# Altium schematic sheet Power.SchDoc
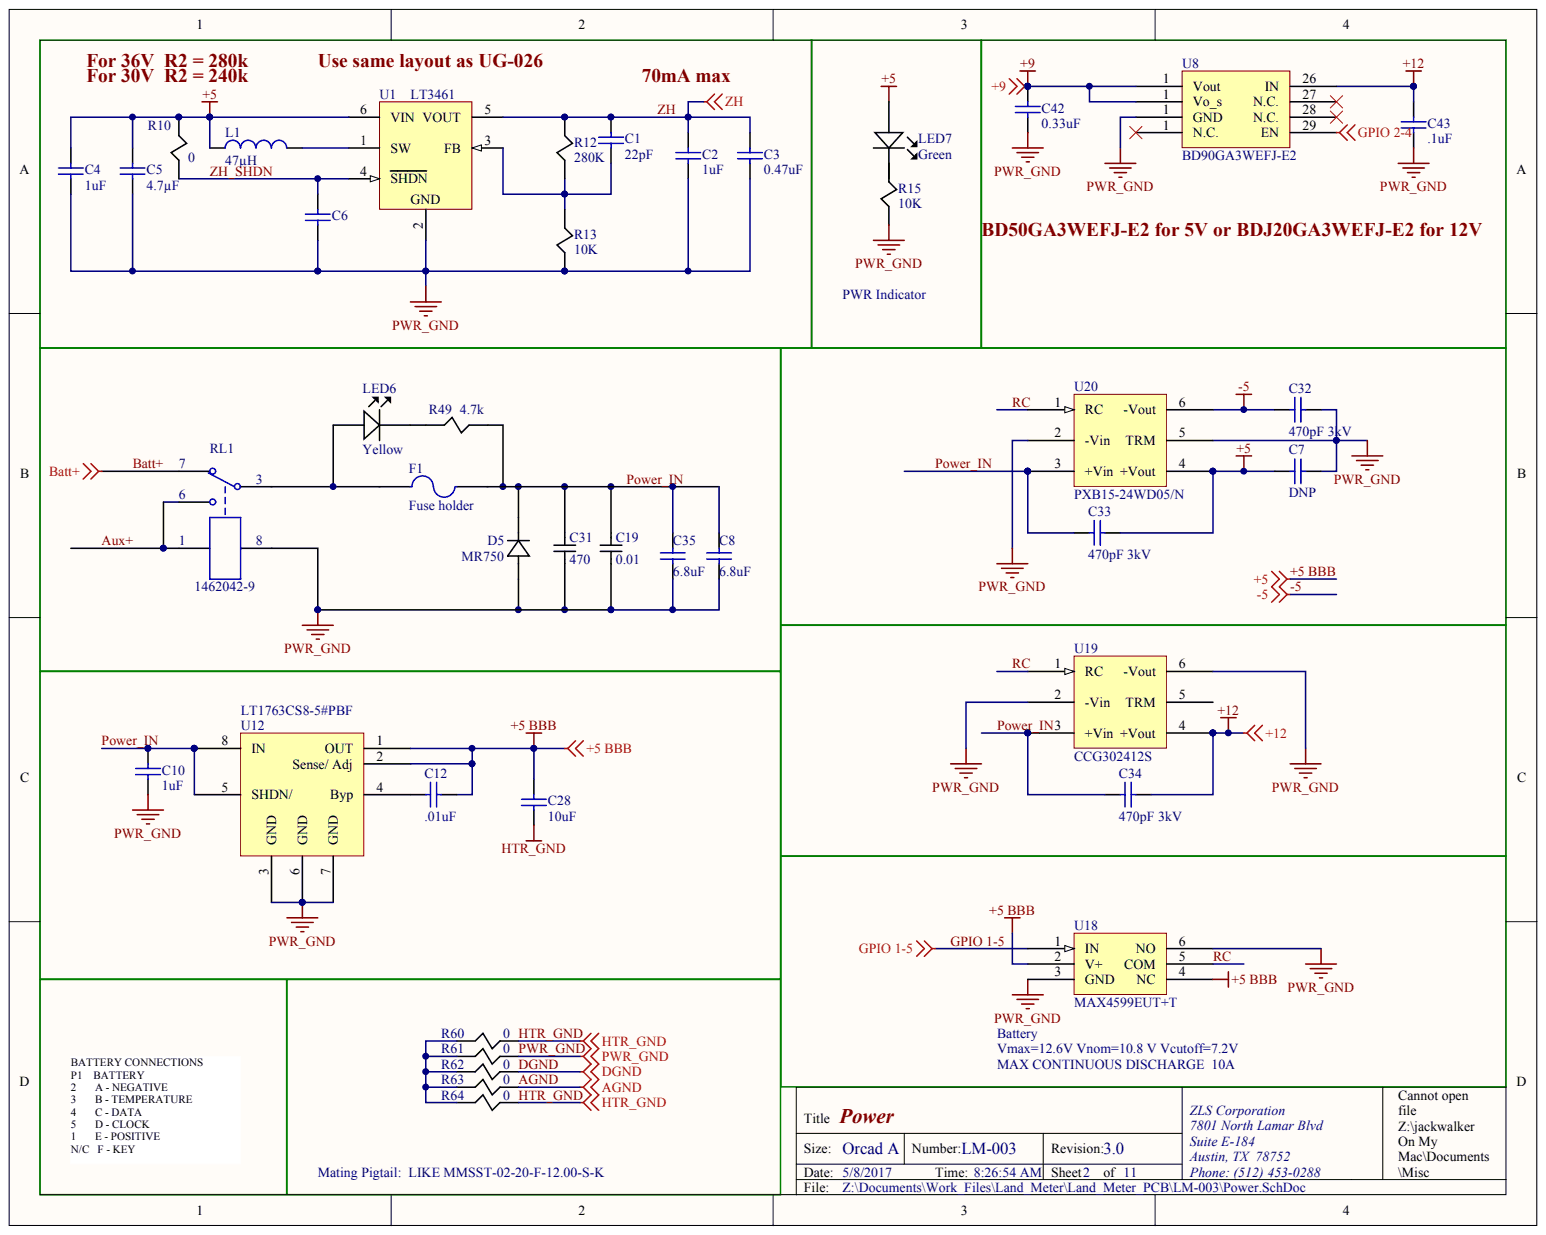
[diagram p0: Power.SchDoc]

## Parts
C1  22pF
C2  1uF
C3  0.47uF
C4  1uF
C5  4.7µF
C6  0.047µF  (value not drawn)
C7  DNP  Capacitance: 470pF
C8  6.8uF
C10  1uF
C12  .01uF
C19  0.01
C28  10uF
C31  470
C32  470pF 3kV  Capacitance: 470pF
C33  470pF 3kV  Capacitance: 470pF
C34  470pF 3kV  Capacitance: 470pF
C35  6.8uF
C42  0.33uF
C43  .1uF
D5  MR750
F1  Fuse holder
L1  47µH
LED6  Yellow
LED7  Green
R10  0
R12  280K
R13  10K
R15  10K
R49  4.7k
R60  0
R61  0
R62  0
R63  0
R64  0
RL1  1462042-9
U1  LT3461
U8  BD90GA3WEFJ-E2
U12  LT1763CS8-5#PBF
U18  MAX4599EUT+T
U19  CCG302412S
U20  PXB15-24WD05/N

## Nets
+5: C4.2 C5.2 C7.1 C33.2 L1.1 LED7.A R10.2 U1.6(VIN) U20.4(+Vout)
+9: C42.2 U8.1
+12: C34.2 C43.2 U8.26(IN) U19.4(+Vout)
GPIO 2-4: U8.29(EN)
+5 BBB: C12.2 C28.2 U12.1(OUT) U12.2(Sense/_Adj) U18.2(V+) U18.4(NC)
-5: C32.1 U20.6(-Vout)
AGND: R63.2
AUX+: RL1.3 RL1.5
BATT+: RL1.2
DGND: R62.2
GPIO 1-5: U18.1(IN)
HTR_GND: C28.1 R60.2 R64.2
POWER_IN: C8.2 C10.2 C19.1 C31.1 C33.1 C34.1 C35.2 D5.K F1.2 R49.2 U12.5(SHDN/) U12.8(IN) U19.3(+Vin) U20.3(+Vin)
PWR_GND: C2.1 C3.1 C4.1 C5.1 C6.1 C7.2 C8.1 C10.1 C19.2 C31.2 C32.2 C35.1 C42.1 C43.1 D5.A R13.1 R15.1 R61.2 RL1.4 U1.2 U8.1 U12.3(GND) U12.6(GND) U12.7(GND) U18.3(GND) U18.6(NO) U19.2(-Vin) U19.6(-Vout) U20.2(-Vin) U20.5(TRM)
RC: U18.5(COM) U19.1(RC) U20.1(RC)
ZH: C1.2 C2.2 C3.2 R12.2 U1.5(VOUT)
ZH_SHDN: C6.2 R10.1 U1.4(SHDN)
NetC1_1: C1.1 R12.1 R13.2 U1.3(FB)
NetC12_1: C12.1 U12.4(Byp)
NetF1_1: F1.1 LED6.A RL1.1
NetL1_2: L1.2 U1.1(SW)
NetLED6_K: LED6.K R49.1
NetR60_1: R60.1 R61.1 R62.1 R63.1 R64.1

Not connected: LED7 K; R15 2; U8 27(N.C.) 28(N.C.); U19 5(TRM)

## Library data of the parts
C1 = (no symbol name): Manufacturer 1: AVX Corporation; Manufacturer Part Number 1: 06035C220JAT2A; Description 1: CAP CER 22PF 50V X7R 0603; Category 1: Capacitors; Tolerance: ±5%; Voltage - Rated: 50V; Temperature Coefficient: X7R; Supplier 1: Digi-Key; Supplier Part Number 1: 478-6204-1-ND; RoHS 1: RoHS Compliant; Stock 1: 63351; Pricing 1: 1=0.2, 10=0.136, 25=0.0996, 50=0.0816, 100=0.0688, 250=0.05616, 500=0.0471, 1000=0.04166 (USD); Packaging: Cut Tape (CT); Part Status: Active; Capacitance: 22pF; Operating Temperature: -55°C ~ 125°C; Features: -; Ratings: -; Applications: General Purpose; Mounting Type: Surface Mount, MLCC; Package / Case: 0603 (1608 Metric); Size / Dimension: 0.063" L x 0.032" W (1.60mm x 0.81mm); Height - Seated (Max): -; Thickness (Max): 0.035" (0.90mm); Lead Spacing: -; Lead Style: -; ComponentLink1URL: http://datasheets.avx.com/X7RDielectric.pdf; ComponentLink1Description: http://datasheets.avx.com/X7RDielectric.pdf
C2 = (no symbol name): Manufacturer 1: Murata Electronics North America; Manufacturer Part Number 1: GRM32RR71H105KA01L; Description 1: CAP CER 1UF 50V X7R 1210; Category 1: Capacitors; Capacitance: 1µF; Tolerance: ±10%; Voltage - Rated: 50V; Temperature Coefficient: X7R; Supplier 1: Digi-Key; Supplier Part Number 1: 490-1863-1-ND; RoHS 1: RoHS Compliant; Stock 1: 29439; Pricing 1: 1=0.35, 10=0.242, 25=0.198, 50=0.169, 100=0.1449, 250=0.12076, 500=0.10868 (USD); Packaging: Cut Tape (CT); Part Status: Active; Operating Temperature: -55°C ~ 125°C; Features: -; Ratings: -; Applications: General Purpose; Mounting Type: Surface Mount, MLCC; Package / Case: 1210 (3225 Metric); Size / Dimension: 0.126" L x 0.098" W (3.20mm x 2.50mm); Height - Seated (Max): -; Thickness (Max): 0.079" (2.00mm); Lead Spacing: -; Lead Style: -; ComponentLink1URL: http://search.murata.co.jp/Ceramy/image/img/A01X/G101/ENG/GRM32RR71H105KA01-01.pdf; ComponentLink1Description: http://search.murata.co.jp/Ceramy/image/img/A01X/G101/ENG/GRM32RR71H105KA01-01.pdf
C3 = (no symbol name): Manufacturer 1: Murata Electronics North America; Manufacturer Part Number 1: GRM31MR71H474KA01L; Description 1: CAP CER 0.47UF 50V X7R 1206; Category 1: Capacitors; Capacitance: 0.47µF; Tolerance: ±10%; Voltage - Rated: 50V; Temperature Coefficient: X7R; Supplier 1: Digi-Key; Supplier Part Number 1: 490-1781-1-ND; RoHS 1: RoHS Compliant; Stock 1: 12711; Pricing 1: 1=0.43, 10=0.305, 25=0.2608, 50=0.2308, 100=0.201, 250=0.17124, 500=0.14892, 1000=0.12658 (USD); Packaging: Cut Tape (CT); Part Status: Active; Operating Temperature: -55°C ~ 125°C; Features: -; Ratings: -; Applications: General Purpose; Mounting Type: Surface Mount, MLCC; Package / Case: 1206 (3216 Metric); Size / Dimension: 0.126" L x 0.063" W (3.20mm x 1.60mm); Height - Seated (Max): -; Thickness (Max): 0.049" (1.25mm); Lead Spacing: -; Lead Style: -; ComponentLink1URL: http://www.murata.com/~/media/webrenewal/support/library/catalog/products/capacitor/mlcc/c02e.ashx; ComponentLink1Description: http://www.murata.com/~/media/webrenewal/support/library/catalog/products/capacitor/mlcc/c02e.ashx
C4 = (no symbol name): Manufacturer 1: Taiyo Yuden; Manufacturer Part Number 1: EMK212B7105KG-T; Description 1: CAP CER 1UF 16V X7R 0805; Category 1: Capacitors; Capacitance: 1µF; Tolerance: ±10%; Voltage - Rated: 16V; Temperature Coefficient: X7R; Supplier 1: Digi-Key; Supplier Part Number 1: 587-1283-1-ND; RoHS 1: RoHS Compliant; Stock 1: 42737; Pricing 1: 1=0.13, 10=0.089, 25=0.0652, 50=0.0508, 100=0.042, 250=0.03476, 500=0.02946, 1000=0.02439 (USD); Packaging: Cut Tape (CT); Part Status: Active; Operating Temperature: -55°C ~ 125°C; Features: -; Ratings: -; Applications: General Purpose; Mounting Type: Surface Mount, MLCC; Package / Case: 0805 (2012 Metric); Size / Dimension: 0.079" L x 0.049" W (2.00mm x 1.25mm); Height - Seated (Max): -; Thickness (Max): 0.053" (1.35mm); Lead Spacing: -; Lead Style: -; ComponentLink1URL: http://www.yuden.co.jp/productdata/catalog/en/mlcc06_e.pdf; ComponentLink1Description: http://www.yuden.co.jp/productdata/catalog/en/mlcc06_e.pdf
C5 = (no symbol name): Manufacturer 1: Taiyo Yuden; Manufacturer Part Number 1: EMK316B7475KL-T; Description 1: CAP CER 4.7UF 16V X7R 1206; Category 1: Capacitors; Tolerance: ±10%; Voltage - Rated: 16V; Temperature Coefficient: X7R; Supplier 1: Digi-Key; Supplier Part Number 1: 587-1331-1-ND; RoHS 1: RoHS Compliant; Stock 1: 42774; Pricing 1: 1=0.25, 10=0.17, 25=0.1364, 50=0.1136, 100=0.0965, 250=0.07664, 500=0.06812, 1000=0.0596 (USD); Packaging: Cut Tape (CT); Part Status: Active; Capacitance: 4.7µF; Operating Temperature: -55°C ~ 125°C; Features: -; Ratings: -; Applications: General Purpose; Mounting Type: Surface Mount, MLCC; Package / Case: 1206 (3216 Metric); Size / Dimension: 0.126" L x 0.063" W (3.20mm x 1.60mm); Height - Seated (Max): -; Thickness (Max): 0.071" (1.80mm); Lead Spacing: -; Lead Style: -; ComponentLink1URL: http://ds.yuden.co.jp/TYCOMPAS/ut/detail.do?productNo=EMK316B7475KL-T&fileName=EMK316B7475KL-T_SS&mode=specSheetDownload; ComponentLink1Description: http://ds.yuden.co.jp/TYCOMPAS/ut/detail.do?productNo=EMK316B7475KL-T&fileName=EMK316B7475KL-T_SS&mode=specSheetDownload
C6 = (no symbol name): Manufacturer 1: Murata Electronics North America; Manufacturer Part Number 1: GRM188R71C473KA01D; Description 1: CAP CER 0.047UF 16V X7R 0603; Category 1: Capacitors; Tolerance: ±10%; Voltage - Rated: 16V; Temperature Coefficient: X7R; Supplier 1: Digi-Key; Supplier Part Number 1: 490-1529-1-ND; RoHS 1: RoHS Compliant; Stock 1: 116533; Pricing 1: 1=0.1, 10=0.044, 25=0.0324, 50=0.0242, 100=0.0198, 250=0.01656, 500=0.01414, 1000=0.01111 (USD); Packaging: Cut Tape (CT); Part Status: Active; Capacitance: 0.047µF; Operating Temperature: -55°C ~ 125°C; Features: -; Ratings: -; Applications: General Purpose; Mounting Type: Surface Mount, MLCC; Package / Case: 0603 (1608 Metric); Size / Dimension: 0.063" L x 0.031" W (1.60mm x 0.80mm); Height - Seated (Max): -; Thickness (Max): 0.035" (0.90mm); Lead Spacing: -; Lead Style: -; ComponentLink1URL: http://www.murata.com/~/media/webrenewal/support/library/catalog/products/capacitor/mlcc/c02e.ashx; ComponentLink1Description: http://www.murata.com/~/media/webrenewal/support/library/catalog/products/capacitor/mlcc/c02e.ashx
C7 C32-C34 = (no symbol name): Manufacturer 1: KEMET; Manufacturer Part Number 1: C1812C471KHRACTU; Description 1: CAP CER 470PF 3KV X7R 1812; Category 1: Capacitors; Tolerance: ±10%; Voltage - Rated: 3000V (3kV); Temperature Coefficient: X7R; Supplier 1: Digi-Key; Supplier Part Number 1: 399-7289-1-ND; RoHS 1: RoHS Compliant; Stock 1: 2780; Pricing 1: 1=0.88, 10=0.666, 25=0.5888, 50=0.553, 100=0.4761, 250=0.43008, 500=0.36862 (USD); Packaging: Cut Tape (CT); Part Status: Active; Operating Temperature: -55°C ~ 125°C; Features: High Voltage; Ratings: -; Applications: SMPS Filtering; Failure Rate: -; Mounting Type: Surface Mount, MLCC; Package / Case: 1812 (4532 Metric); Size / Dimension: 0.177" L x 0.126" W (4.50mm x 3.20mm); Height - Seated (Max): -; Thickness (Max): 0.071" (1.80mm); Lead Spacing: -; Lead Style: -; ComponentLink1URL: http://www.kemet.com/docfinder?Partnumber=C1812C471KHRACTU; ComponentLink1Description: http://www.kemet.com/docfinder?Partnumber=C1812C471KHRACTU
C8 C35 = (no symbol name): Manufacturer 1: TDK Corporation; Manufacturer Part Number 1: C3216X5R1H685K160AB; Description 1: CAP CER 6.8UF 50V X5R 1206; Category 1: Capacitors; Capacitance: 6.8µF; Tolerance: ±10%; Voltage - Rated: 50V; Temperature Coefficient: X5R; Supplier 1: Digi-Key; Supplier Part Number 1: 445-5996-1-ND; RoHS 1: RoHS Compliant; Stock 1: 31780; Pricing 1: 1=1.03, 10=0.785, 25=0.6944, 50=0.652, 100=0.5615, 250=0.50716, 500=0.4347, 1000=0.37433 (USD); Packaging: Cut Tape (CT); Part Status: Active; Operating Temperature: -55°C ~ 85°C; Features: Low ESL; Ratings: -; Applications: General Purpose; Failure Rate: -; Mounting Type: Surface Mount, MLCC; Package / Case: 1206 (3216 Metric); Size / Dimension: 0.126" L x 0.063" W (3.20mm x 1.60mm); Height - Seated (Max): -; Thickness (Max): 0.071" (1.80mm); Lead Spacing: -; Lead Style: -; ComponentLink1URL: https://product.tdk.com/info/en/catalog/datasheets/mlcc_commercial_general_en.pdf; ComponentLink1Description: https://product.tdk.com/info/en/catalog/datasheets/mlcc_commercial_general_en.pdf
C10 C42 C43 = (no symbol name): Manufacturer 1: Murata Electronics North America; Manufacturer Part Number 1: GRM32RR71H105KA01L; Description 1: CAP CER 1UF 50V 10% X7R 1210; Category 1: Capacitors; Capacitance: 1µF; Tolerance: ±10%; Voltage - Rated: 50V; Temperature Coefficient: X7R; Published: 8-Jun-2000; LatestRevisionDate: 17-Jul-2002; LatestRevisionNote: Re-released for DXP Platform.; PackageReference: RAD-0.3; PackageDescription: Radial Cap, Thru-Hole; 2 Leads; 0.3 in Pin Spacing; Supplier 1: Digi-Key; Supplier Part Number 1: 490-1863-1-ND; RoHS 1: RoHS Compliant; Stock 1: 39973; Pricing 1: 1=0.36, 10=0.319, 50=0.1934, 100=0.1593, 250=0.1274, 500=0.11602 (USD); Packaging: Cut Tape (CT); Mounting Type: Surface Mount, MLCC; Operating Temperature: -55°C ~ 125°C; Applications: General Purpose; Ratings: -; Package / Case: 1210 (3225 Metric); Size / Dimension: 0.126" L x 0.098" W (3.20mm x 2.50mm); Height - Seated (Max): -; Thickness (Max): 0.079" (2.00mm); Lead Spacing: -; Features: -; Lead Style: -; ComponentLink1URL: http://www.murata.com/~/media/webrenewal/support/library/catalog/products/capacitor/mlcc/c02e.ashx; ComponentLink1Description: http://www.murata.com/~/media/webrenewal/support/library/catalog/products/capacitor/mlcc/c02e.ashx; Publisher: Altium Limited
  C10: Value: 1uF
  C42: Value: 0.33uF
  C43: Value: .1uF
C12 = (no symbol name): Manufacturer 1: Murata Electronics North America; Manufacturer Part Number 1: GRM216R71E103KA01D; Description 1: CAP CER 10000PF 25V X7R 0805; Category 1: Capacitors; Capacitance: 10000pF; Tolerance: ±10%; Voltage - Rated: 25V; Temperature Coefficient: X7R; Supplier 1: Digi-Key; Supplier Part Number 1: 490-12748-1-ND; RoHS 1: RoHS Compliant; Stock 1: 1263; Pricing 1: 1=0.11, 10=0.077, 25=0.056, 50=0.042, 100=0.0343, 250=0.02872, 500=0.02452, 1000=0.01926 (USD); Packaging: Cut Tape (CT); Part Status: Active; Operating Temperature: -55°C ~ 125°C; Features: -; Ratings: -; Applications: General Purpose; Mounting Type: Surface Mount, MLCC; Package / Case: 0805 (2012 Metric); Size / Dimension: 0.079" L x 0.049" W (2.00mm x 1.25mm); Height - Seated (Max): -; Thickness (Max): 0.028" (0.70mm); Lead Spacing: -; Lead Style: -; ComponentLink1URL: http://www.murata.com/~/media/webrenewal/support/library/catalog/products/capacitor/mlcc/c02e.ashx; ComponentLink1Description: http://www.murata.com/~/media/webrenewal/support/library/catalog/products/capacitor/mlcc/c02e.ashx
C19 = (no symbol name): Manufacturer 1: Murata Electronics North America; Manufacturer Part Number 1: GRM155R71H103KA88D; Description 1: CAP CER 10000PF 50V 10% X7R 0402; Category 1: Capacitors; Capacitance: 10000pF; Tolerance: ±10%; Voltage - Rated: 50V; Temperature Coefficient: X7R; Supplier 1: Digi-Key; Supplier Part Number 1: 490-4516-2-ND; RoHS 1: RoHS Compliant; Stock 1: 652600; Pricing 1: 10000=0.00372, 20000=0.00354, 30000=0.00336, 50000=0.00301, 100000=0.00243 (USD); Packaging: Tape & Reel (TR); Mounting Type: Surface Mount, MLCC; Operating Temperature: -55°C ~ 125°C; Applications: General Purpose; Ratings: -; Package / Case: 0402 (1005 Metric); Size / Dimension: 0.039" L x 0.020" W (1.00mm x 0.50mm); Height - Seated (Max): -; Thickness (Max): 0.022" (0.55mm); Lead Spacing: -; Features: -; Lead Style: -; ComponentLink1URL: http://www.murata.com/products/catalog/pdf/c02e.pdf; ComponentLink1Description: http://www.murata.com/products/catalog/pdf/c02e.pdf
C28 = (no symbol name): Manufacturer 1: Murata Electronics North America; Manufacturer Part Number 1: GCM21BR71A106KE22L; Description 1: CAP CER 10UF 10V X7R 0805; Category 1: Capacitors; Capacitance: 10µF; Tolerance: ±10%; Voltage - Rated: 10V; Temperature Coefficient: X7R; Supplier 1: Digi-Key; Supplier Part Number 1: 490-10674-1-ND; RoHS 1: RoHS Compliant; Stock 1: 168608; Pricing 1: 1=0.47, 10=0.33, 25=0.2816, 50=0.2496, 100=0.2174, 250=0.18516, 500=0.161, 1000=0.13685 (USD); Packaging: Cut Tape (CT); Part Status: Active; Operating Temperature: -55°C ~ 125°C; Features: -; Ratings: AEC-Q200; Applications: Automotive; Mounting Type: Surface Mount, MLCC; Package / Case: 0805 (2012 Metric); Size / Dimension: 0.079" L x 0.049" W (2.00mm x 1.25mm); Height - Seated (Max): -; Thickness (Max): 0.055" (1.40mm); Lead Spacing: -; Lead Style: -; ComponentLink1URL: http://search.murata.co.jp/Ceramy/image/img/A01X/G101/ENG/GCM21BR71A106KE22-01.pdf; ComponentLink1Description: http://search.murata.co.jp/Ceramy/image/img/A01X/G101/ENG/GCM21BR71A106KE22-01.pdf
C31 = (no symbol name): Manufacturer 1: Lelon; Manufacturer Part Number 1: TEA471M1CBK-0816P; Description 1: Aluminum Electrolytic Capacitors - Leaded 16V 470uF 20%; Category 1: Aluminum Electrolytic Capacitors - Leaded; Capacitance: 470 uF; Tolerance: 20 %; Voltage Rating DC: 16 V; Case Diameter: 8 mm; Case Length: 16 mm; Dissipation Factor DF: 0.17; Load Life: 2000 h; Ripple Current: 460 mA; Product Status: Factory Special Order; Supplier 1: Mouser; Supplier Part Number 1: 140-TEA471M1CBK0816P; RoHS 1: RoHS Compliant; Stock 1: 0; Dimensions: 8 mm Dia. x 16 mm L; Product: General Purpose Electrolytic Capacitors; Series: TEA; Termination Style: Axial; Brand: Lelon; Maximum Operating Temperature: + 85 C; Product Category: Axial Electrolytic Capacitors; Standard Pack Qty: 500; RoHS - Mouser: Y; Minimum Order Quantity: 2000; Reeling: False; ComponentLink1URL: http://www.mouser.com/catalog/specsheets/ea.pdf; ComponentLink1Description: http://www.mouser.com/catalog/specsheets/ea.pdf
D5 = (no symbol name): Manufacturer 1: ON Semiconductor; Description 1: DIODE GP 50V 6A MICRODE BUTTON; Category 1: Discrete Semiconductor Products; Diode Type: Standard; Voltage - DC Reverse (Vr) (Max): 50V; Current - Average Rectified (Io): 6A; Voltage - Forward (Vf) (Max) @ If: 900mV @ 6A; Speed: Standard Recovery >500ns, > 200mA (Io); Current - Reverse Leakage @ Vr: 25µA @ 50V; Supplier 1: Digi-Key; Supplier Part Number 1: MR750-ND; Manufacturer Part Number 1: MR750; RoHS 1: RoHS non-compliant; Stock 1: 0; Pricing 1: 1000=0 (USD); Packaging: Bulk; Reverse Recovery Time (trr): -; Capacitance @ Vr, F: -; Mounting Type: Through Hole; Package / Case: Button, Axial; Supplier Device Package: Microde Button; Operating Temperature - Junction: -65°C ~ 175°C; ComponentLink1URL: http://www.onsemi.com/pub_link/Collateral/MR750-D.PDF; ComponentLink1Description: http://www.onsemi.com/pub_link/Collateral/MR750-D.PDF
F1 = (no symbol name): Manufacturer 1: Littelfuse Inc.; Manufacturer Part Number 1: 01530007Z; Description 1: FUSE HOLDER BLADE 32V 15A PCB; Category 1: Circuit Protection; Fuse Type: Blade; For Use With/Related Products: MINI ATC, MINI ATO; Fuse Size: 0.43" L x 0.15" W x 0.64" H (10.92mm x 3.81mm x 16.25mm); Number of Circuits: 1; Voltage: 32V; Current Rating: 15A; Supplier 1: Digi-Key; Supplier Part Number 1: F064-ND; RoHS 1: RoHS Compliant; Stock 1: 4234; Pricing 1: 1=3.45, 5=3.336, 10=3.22, 50=2.53, 100=2.07, 250=2.01252, 500=1.725, 1000=1.61, 5000=1.4375 (USD); Part Status: Active; Fuseholder Type: Holder; Mounting Type: PCB; Orientation: Horizontal; Termination Style: PC Pin; Contact Material: Copper Alloy; Contact Finish: Tin; ComponentLink1URL: http://www.littelfuse.com/~/media/commercial-vehicle/datasheets/automotive-fuse-holders/mini/littelfuse_fuse-holders-mini-153-pc-datasheet.pdf; ComponentLink1Description: http://www.littelfuse.com/~/media/commercial-vehicle/datasheets/automotive-fuse-holders/mini/littelfuse_fuse-holders-mini-153-pc-datasheet.pdf
L1 = (no symbol name): Manufacturer 1: Murata Electronics North America; Manufacturer Part Number 1: LQH32CN470K23L; Description 1: FIXED IND 47UH 170MA 1.3 OHM SMD; Category 1: Inductors, Coils, Chokes; Type: Wirewound; Material - Core: Ferrite; Tolerance: ±10%; Current Rating: 170mA; Shielding: Unshielded; DC Resistance (DCR): 1.3 Ohm; Frequency - Self Resonant: 15MHz; Frequency - Test: 1MHz; Supplier 1: Digi-Key; Supplier Part Number 1: 490-2497-1-ND; RoHS 1: RoHS Compliant; Stock 1: 39785; Pricing 1: 1=0.32, 10=0.291, 25=0.2584, 50=0.2262, 100=0.21, 250=0.1938, 500=0.18412, 750=0.16796, 1000=0.14858 (USD); Packaging: Cut Tape (CT); Inductance: 47µH; Current - Saturation: -; Q @ Freq: -; Operating Temperature: -40°C ~ 85°C; Mounting Type: Surface Mount; Package / Case: 1210 (3225 Metric); Size / Dimension: 0.126" L x 0.098" W (3.20mm x 2.50mm); Height - Seated (Max): 0.067" (1.70mm); Supplier Device Package: 1210; ComponentLink1URL: http://search.murata.co.jp/Ceramy/image/img/PDF/ENG/L0075S0093LQH32C_23~33.pdf; ComponentLink1Description: http://search.murata.co.jp/Ceramy/image/img/PDF/ENG/L0075S0093LQH32C_23~33.pdf
LED6 = (no symbol name): Manufacturer 1: Dialight; Manufacturer Part Number 1: 5660306F; Description 1: LED 2MM X 5MM RT ANGLE YEL PCMNT; Category 1: Optoelectronics; Wavelength - Peak: 583nm; Configuration: Single; Current: 20mA; Millicandela Rating: 3.5mcd; Viewing Angle: 110°; Lens Type: Diffused, Tinted; Lens Style/Size: Rectangle with Flat Top, 5.00mm x 2.00mm; Voltage Rating: 2.1V; Supplier 1: Digi-Key; Supplier Part Number 1: 350-1764-ND; RoHS 1: RoHS Compliant; Stock 1: 594; Pricing 1: 1=1.85, 10=1.507, 25=1.1588, 100=1.0431, 250=0.9272, 500=0.7881, 1000=0.64903, 2500=0.61658, 5000=0.60267 (USD); Packaging: Bulk; Part Status: Active; Color: Yellow; Mounting Type: Through Hole, Right Angle; ComponentLink1URL: http://www.dialight.com/Assets/Brochures_And_Catalogs/Indication/566-xx06.pdf; ComponentLink1Description: http://www.dialight.com/Assets/Brochures_And_Catalogs/Indication/566-xx06.pdf
LED7 = (no symbol name): Manufacturer 1: Dialight; Manufacturer Part Number 1: 5660206F; Description 1: LED 2MM X 5MM RT ANGLE GRN PCMNT; Category 1: Optoelectronics; Wavelength - Peak: 565nm; Configuration: Single; Current: 20mA; Millicandela Rating: 4mcd; Viewing Angle: 110°; Lens Type: Diffused, Tinted; Lens Style/Size: Rectangle with Flat Top, 5.00mm x 2.00mm; Voltage Rating: 2.2V; Supplier 1: Digi-Key; Supplier Part Number 1: 350-1762-ND; RoHS 1: RoHS Compliant; Stock 1: 807; Pricing 1: 1=1.85, 10=1.507, 25=1.1588, 100=1.0431, 250=0.9272, 500=0.7881, 1000=0.64903, 2500=0.61658, 5000=0.60267 (USD); Packaging: Bulk; Part Status: Active; Color: Green; Mounting Type: Through Hole, Right Angle; ComponentLink1URL: http://www.dialight.com/Assets/Brochures_And_Catalogs/Indication/566-xx06.pdf; ComponentLink1Description: http://www.dialight.com/Assets/Brochures_And_Catalogs/Indication/566-xx06.pdf
R10 R60-R64 = (no symbol name): Manufacturer 1: Vishay Dale; Manufacturer Part Number 1: CRCW08050000Z0EA; Description 1: RES SMD 0.0 OHM JUMPER 1/8W 0805; Category 1: Resistors; Resistance (Ohms): 0.0; Tolerance: Jumper; Power (Watts): 0.125W, 1/8W; Supplier 1: Digi-Key; Supplier Part Number 1: 541-0.0ACT-ND; RoHS 1: RoHS Compliant; Stock 1: 1008635; Pricing 1: 1=0.1, 10=0.026, 25=0.0184, 50=0.014, 100=0.0104, 250=0.00792, 500=0.00634, 1000=0.00466 (USD); Packaging: Cut Tape (CT); Part Status: Active; Composition: Thick Film; Features: Automotive AEC-Q200; Temperature Coefficient: -; Operating Temperature: -55°C ~ 155°C; Package / Case: 0805 (2012 Metric); Supplier Device Package: 0805; Size / Dimension: 0.079" L x 0.049" W (2.00mm x 1.25mm); Height - Seated (Max): 0.020" (0.50mm); Failure Rate: -; ComponentLink1URL: http://www.vishay.com/docs/20035/dcrcwe3.pdf; ComponentLink1Description: http://www.vishay.com/docs/20035/dcrcwe3.pdf
R12 = (no symbol name): Manufacturer 1: Vishay Dale; Manufacturer Part Number 1: CRCW0805280KFKEA; Description 1: RES SMD 280K OHM 1% 1/8W 0805; Category 1: Resistors; Tolerance: ±1%; Power (Watts): 0.125W, 1/8W; Temperature Coefficient: ±100ppm/°C; Supplier 1: Digi-Key; Supplier Part Number 1: 541-280KCCT-ND; RoHS 1: RoHS Compliant; Stock 1: 661; Pricing 1: 1=0.1, 10=0.042, 25=0.0304, 50=0.0232, 100=0.017, 250=0.013, 500=0.0104, 1000=0.00765 (USD); Packaging: Cut Tape (CT); Part Status: Active; Resistance (Ohms): 280k; Composition: Thick Film; Features: Automotive AEC-Q200; Operating Temperature: -55°C ~ 155°C; Package / Case: 0805 (2012 Metric); Supplier Device Package: 0805; Size / Dimension: 0.079" L x 0.049" W (2.00mm x 1.25mm); Height - Seated (Max): 0.020" (0.50mm); Failure Rate: -; ComponentLink1URL: http://www.vishay.com/docs/20035/dcrcwe3.pdf; ComponentLink1Description: http://www.vishay.com/docs/20035/dcrcwe3.pdf
R13 = (no symbol name): Manufacturer 1: Vishay Dale; Manufacturer Part Number 1: CRCW080510K0FKTA; Description 1: RES SMD 10K OHM 1% 1/8W 0805; Category 1: Resistors; Tolerance: ±1%; Power (Watts): 0.125W, 1/8W; Temperature Coefficient: ±100ppm/°C; Supplier 1: Digi-Key; Supplier Part Number 1: 541-3016-1-ND; RoHS 1: RoHS non-compliant; Stock 1: 11388; Pricing 1: 1=0.14, 10=0.123, 25=0.0852, 50=0.0662, 100=0.0474, 250=0.03692, 500=0.02936, 1000=0.02084 (USD); Packaging: Cut Tape (CT); Part Status: Active; Resistance (Ohms): 10k; Composition: Thick Film; Features: Automotive AEC-Q200; Operating Temperature: -55°C ~ 155°C; Package / Case: 0805 (2012 Metric); Supplier Device Package: 0805; Size / Dimension: 0.079" L x 0.049" W (2.00mm x 1.25mm); Height - Seated (Max): 0.020" (0.50mm); Failure Rate: -; ComponentLink1URL: http://www.vishay.com/docs/20008/dcrcw.pdf; ComponentLink1Description: http://www.vishay.com/docs/20008/dcrcw.pdf
R15 = (no symbol name): Manufacturer 1: Vishay Dale; Manufacturer Part Number 1: CRCW080510K0FKEC; Description 1: RES SMD 10K OHM 1% 1/8W 0805; Category 1: Resistors; Tolerance: ±1%; Power (Watts): 0.125W, 1/8W; Temperature Coefficient: ±100ppm/°C; Supplier 1: Digi-Key; Supplier Part Number 1: 541-3015-1-ND; RoHS 1: RoHS Compliant; Stock 1: 13410; Pricing 1: 1=0.1, 10=0.042, 25=0.0304, 50=0.0232, 100=0.017, 250=0.013, 500=0.0104, 1000=0.00765, 5000=0.00549 (USD); Packaging: Cut Tape (CT); Part Status: Active; Resistance (Ohms): 10k; Composition: Thick Film; Features: Automotive AEC-Q200; Operating Temperature: -55°C ~ 155°C; Package / Case: 0805 (2012 Metric); Supplier Device Package: 0805; Size / Dimension: 0.079" L x 0.049" W (2.00mm x 1.25mm); Height - Seated (Max): 0.020" (0.50mm); Failure Rate: -; ComponentLink1URL: http://www.vishay.com/docs/20035/dcrcwe3.pdf; ComponentLink1Description: http://www.vishay.com/docs/20035/dcrcwe3.pdf
R49 = (no symbol name): Manufacturer 1: Vishay Dale; Manufacturer Part Number 1: CRCW08054K70FKEA; Description 1: RES SMD 4.7K OHM 1% 1/8W 0805; Category 1: Resistors; Tolerance: ±1%; Power (Watts): 0.125W, 1/8W; Temperature Coefficient: ±100ppm/°C; Supplier 1: Digi-Key; Supplier Part Number 1: 541-4.70KCCT-ND; RoHS 1: RoHS Compliant; Stock 1: 44456; Pricing 1: 1=0.1, 10=0.042, 25=0.0304, 50=0.0232, 100=0.017, 250=0.013, 500=0.0104, 1000=0.00765 (USD); Packaging: Cut Tape (CT); Part Status: Active; Resistance (Ohms): 4.7k; Composition: Thick Film; Features: Automotive AEC-Q200; Operating Temperature: -55°C ~ 155°C; Package / Case: 0805 (2012 Metric); Supplier Device Package: 0805; Size / Dimension: 0.079" L x 0.049" W (2.00mm x 1.25mm); Height - Seated (Max): 0.020" (0.50mm); Failure Rate: -; ComponentLink1URL: http://www.vishay.com/docs/20035/dcrcwe3.pdf; ComponentLink1Description: http://www.vishay.com/docs/20035/dcrcwe3.pdf
RL1 = (no symbol name): Manufacturer 1: TE Connectivity Potter & Brumfield Relays; Description 1: RELAY TELECOM SPDT 2A 12V; Category 1: Relays; Relay Type: Telecom; Coil Type: Non Latching; Coil Voltage: 12VDC; Contact Form: SPDT (1 Form C); Switching Voltage: 250VAC, 220VDC - Max; Turn On Voltage (Max): 9 VDC; Turn Off Voltage (Min): 1.2 VDC; Coil Power: 140 mW; Coil Resistance: 1.03 kOhms; Supplier 1: Digi-Key; Supplier Part Number 1: PB1250CT-ND; Manufacturer Part Number 1: 1462042-9; RoHS 1: RoHS Compliant; Stock 1: 15694; Pricing 1: 1=4.25, 10=3.98, 25=3.5376, 50=3.3608, 100=3.1838, 250=2.94064 (USD); Packaging: Cut Tape (CT); Part Status: Active; Features: Sealed - Hermetically; Mounting Type: Surface Mount; Termination Style: Gull Wing; Contact Material: Palladium (Pd), Ruthenium (Ru), Gold (Au); Operating Temperature: -40°C ~ 85°C; ComponentLink1URL: http://www.te.com/commerce/DocumentDelivery/DDEController?Action=srchrtrv&DocNm=1462042-2&DocType=Customer+Drawing&DocLang=English; ComponentLink1Description: http://www.te.com/commerce/DocumentDelivery/DDEController?Action=srchrtrv&DocNm=1462042-2&DocType=Customer+Drawing&DocLang=English
U1 = (no symbol name): Manufacturer 1: Linear Technology; Manufacturer Part Number 1: LT3461AES6#TRMPBF; Description 1: IC REG BOOST ADJ 0.3A TSOT23-6; Category 1: Integrated Circuits (ICs); Function: Step-Up; Output Configuration: Positive; Topology: Boost; Output Type: Adjustable; Number of Outputs: 1; Voltage - Input (Min): 2.5V; Voltage - Input (Max): 16V; Voltage - Output (Min/Fixed): 1.255V; Voltage - Output (Max): 38V; Current - Output: 300mA (Switch); Frequency - Switching: 3MHz; Synchronous Rectifier: No; Supplier 1: Digi-Key; Supplier Part Number 1: LT3461AES6#TRMPBFCT-ND; RoHS 1: RoHS Compliant; Stock 1: 1325; Pricing 1: 1=3.94, 25=3.52, 100=2.4, 250=2.2 (USD); Packaging: Cut Tape (CT); Part Status: Active; Operating Temperature: 0°C ~ 70°C (TA); Mounting Type: Surface Mount; Package / Case: SOT-23-6 Thin, TSOT-23-6; Supplier Device Package: TSOT-23-6; ComponentLink1URL: http://www.linear.com/docs/3469; ComponentLink1Description: http://www.linear.com/docs/3469
U8 = (no symbol name): Description 2: IC REG LDO 9V 0.3A 8HTSOP-J; Category 2: Integrated Circuits (ICs); Regulator Topology: Positive Fixed; Voltage - Output: 9V; Current - Output: 300mA; Voltage - Dropout (Typical): 0.6V @ 300mA; Voltage - Input: Up to 14V; Supplier 1: Digi-Key; Supplier Part Number 1: BD90GA3WEFJ-E2DKR-ND; Supplier 2: Digi-Key; Supplier Part Number 2: BD90GA3WEFJ-E2CT-ND; Manufacturer 2: Rohm Semiconductor; Manufacturer Part Number 2: BD90GA3WEFJ-E2; RoHS 2: RoHS Compliant; Stock 2: 4781; Pricing 2: 1=0.69, 10=0.606, 25=0.5364, 100=0.4672, 250=0.40664, 500=0.34608, 1000=0.27686 (USD); Packaging: Cut Tape (CT); Part Status: Active; Current - Limit (Min): -; Operating Temperature: -25°C ~ 85°C; Mounting Type: Surface Mount; Package / Case: 8-SOIC (0.154", 3.90mm Width) Exposed Pad; Supplier Device Package: 8-HTSOP-J; ComponentLink1URL: http://rohmfs.rohm.com/en/products/databook/datasheet/ic/power/linear_regulator/bdxxga3wefj-e.pdf; ComponentLink1Description: http://rohmfs.rohm.com/en/products/databook/datasheet/ic/power/linear_regulator/bdxxga3wefj-e.pdf
U12 = (no symbol name): Manufacturer 1: Linear Technology; Description 1: IC REG LDO 5V 0.5A 8SOIC; Category 1: Integrated Circuits (ICs); Regulator Topology: Positive Fixed; Voltage - Output: 5V; Current - Output: 500mA; Voltage - Dropout (Typical): 0.3V @ 500mA; Voltage - Input: Up to 20V; Current - Limit (Min): 520mA; Supplier 1: Digi-Key; Supplier Part Number 1: LT1763CS8-5#PBF-ND; Manufacturer Part Number 1: LT1763CS8-5#PBF; RoHS 1: RoHS Compliant; Stock 1: 3565; Pricing 1: 1=4.15, 25=2.75, 100=2.07 (USD); Packaging: Tube; Part Status: Active; Operating Temperature: -40°C ~ 125°C; Mounting Type: Surface Mount; Package / Case: 8-SOIC (0.154", 3.90mm Width); Supplier Device Package: 8-SOIC; ComponentLink1URL: http://www.linear.com/docs/3903; ComponentLink1Description: http://www.linear.com/docs/3903
U18 = (no symbol name): Manufacturer 1: Maxim Integrated; Description 1: IC SWITCH SPDT SOT23-6; Category 1: Integrated Circuits (ICs); Switch Circuit: SPDT; Multiplexer/Demultiplexer Circuit: 2:1; Number of Circuits: 1; On-State Resistance (Max): 60 Ohm; Channel-to-Channel Matching (&Delta;Ron): 200 mOhm; Voltage - Supply, Single (V+): 2 V ~ 5.5 V; Switch Time (Ton, Toff) (Max): 30ns, 25ns; -3db Bandwidth: 200MHz; Charge Injection: 3pC; Channel Capacitance (CS(off), CD(off)): 8pF, 8pF; Current - Leakage (IS(off)) (Max): 500pA; Supplier 1: Digi-Key; Supplier Part Number 1: MAX4599EUT+CT-ND; Manufacturer Part Number 1: MAX4599EUT+T; RoHS 1: RoHS Compliant; Stock 1: 6290; Pricing 1: 1=1.97, 10=1.86, 25=1.49, 50=1.41, 100=1.3, 250=1.24, 500=1.19 (USD); Packaging: Cut Tape (CT); Part Status: Active; Voltage - Supply, Dual (V±): -; Crosstalk: -; Operating Temperature: -40°C ~ 85°C (TA); Package / Case: SOT-23-6; Supplier Device Package: SOT-23-6; ComponentLink1URL: https://datasheets.maximintegrated.com/en/ds/MAX4599.pdf; ComponentLink1Description: https://datasheets.maximintegrated.com/en/ds/MAX4599.pdf
U19 = (no symbol name): Power (Watts) - Manufacture Series: 30W; Manufacturer 1: TDK-Lambda Americas Inc; Number of Outputs: 1; Voltage - Input (Min): 9V; Voltage - Input (Max): 36V; Voltage - Output 1: 12V; Current - Output (Max): 2.5A; Voltage - Isolation: 1.5kV (1500V); Efficiency: 89%; Description 1: DC/DC CONVERTER 12V 2.5A PCB; Category 1: Power Supplies - Board Mount; Packaging: Tube; Type: Isolated Module; Voltage - Output 2: -; Voltage - Output 3: -; Features: Remote On/Off, OCP; Mounting Type: Through Hole; Package / Case: 6-DIP Module (6 Leads); Size / Dimension: 1.00" L x 1.00" W x 0.39" H (25.4mm x 25.4mm x 9.9mm); Operating Temperature: -40°C ~ 85°C; Power (Watts) - Max: 30W; ComponentLink1URL: http://www.us.tdk-lambda.com/ftp/specs/ccg30.pdf; ComponentLink1Description: http://www.us.tdk-lambda.com/ftp/specs/ccg30.pdf; Supplier 1: Digi-Key; Supplier Part Number 1: 285-2419-ND; Manufacturer Part Number 1: CCG302412S; RoHS 1: RoHS Compliant; Stock 1: 30; Pricing 1: 1=43.63, 10=42.95 (USD)
U20 = (no symbol name): Manufacturer 1: TDK-Lambda Americas Inc.; Description 1: IC CONV DC-DC 15W DUAL 5V PCB; Category 1: Power Supplies - Board Mount; Number of Outputs: 2; Voltage - Input (Min): 9V; Voltage - Input (Max): 36V; Voltage - Output 1: 5V; Voltage - Output 2: -5V; Current - Output (Max): 1.5A, 1.5A; Power (Watts): 15W; Voltage - Isolation: 1.6kV (1600V); Efficiency: 85%; Supplier 1: Digi-Key; Supplier Part Number 1: 285-1902-ND; Manufacturer Part Number 1: PXB15-24WD05/N; RoHS 1: RoHS Compliant; Stock 1: 28; Pricing 1: 1=61.61, 10=59.59 (USD); Packaging: Tube; Part Status: Active; Type: Isolated Module; Voltage - Output 3: -; Applications: ITE (Commercial); Features: Remote On/Off, OCP, OVP, SCP; Operating Temperature: -40°C ~ 85°C; Mounting Type: Through Hole; Package / Case: 6-DIP Module; Size / Dimension: 1.00" L x 1.00" W x 0.39" H (25.4mm x 25.4mm x 9.9mm); ComponentLink1URL: http://us.tdk-lambda.com/ftp/AppNotes/pxb15w-d_appnote.pdf; ComponentLink1Description: http://us.tdk-lambda.com/ftp/AppNotes/pxb15w-d_appnote.pdf
